# Altium schematic sheet E4_V1.0.SchDoc(E4_V1.0)
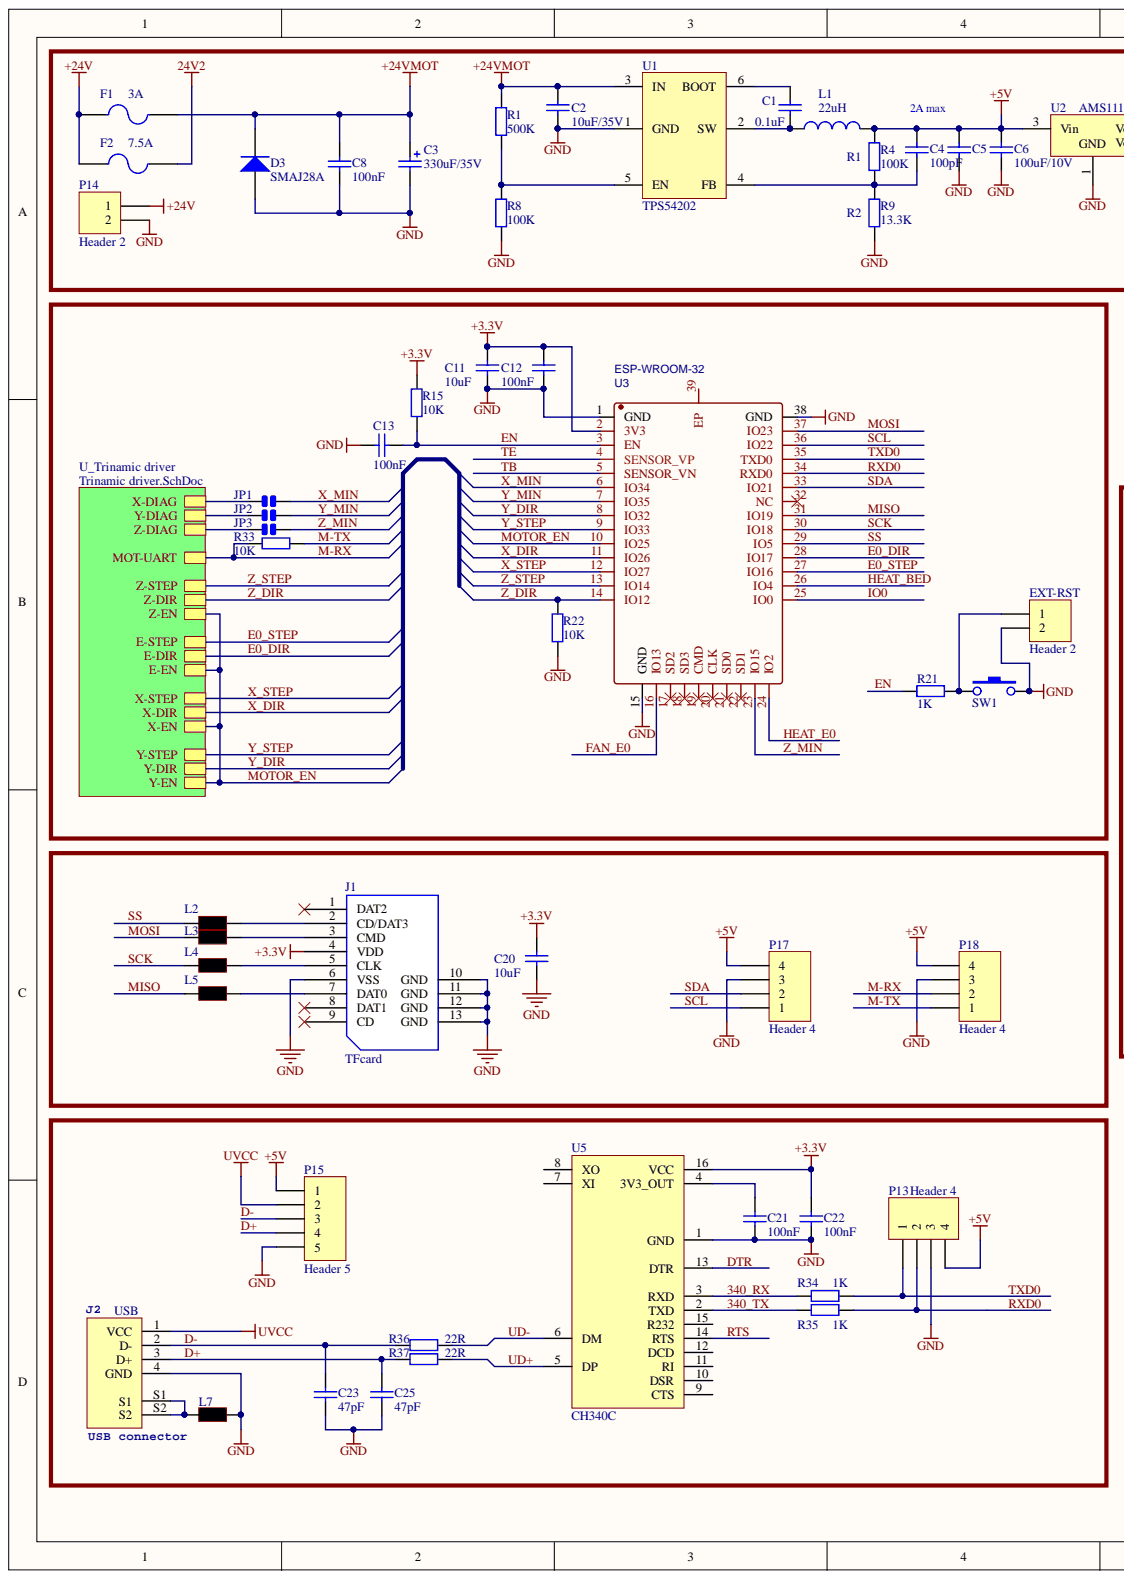
[diagram p0: E4_V1.0.SchDoc, left]
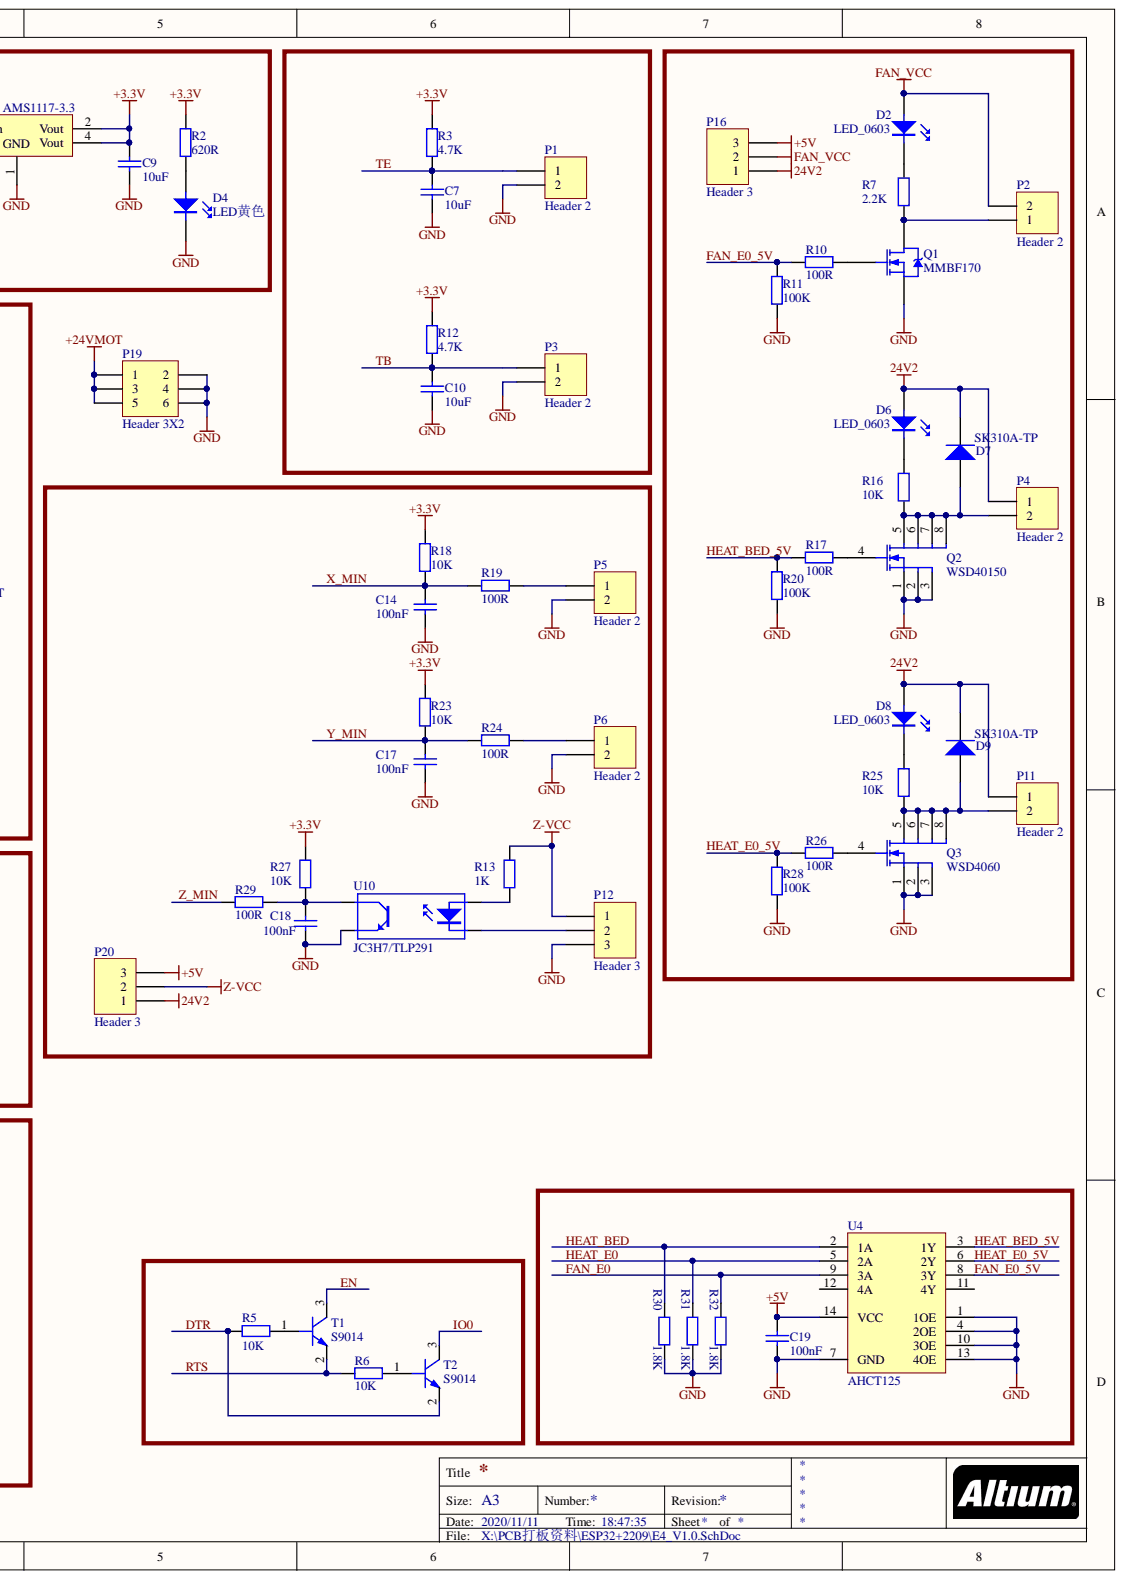
[diagram p1: E4_V1.0.SchDoc, right]

## Parts
C1  0.1uF  [CAP0402]
C2  10uF/35V  [CAP1206]
C3  330uF/35V  [CASE_E7]
C4  100pF  [CAP0402]
C5  100uF/10V  [CAP1206]
C6  100uF/10V  [CAP1206]
C7  10uF  [CAP0805]
C8  100nF  [CAP0603]
C9  10uF  [CAP0805]
C10  10uF  [CAP0805]
C11  10uF  [CAP0805]
C12  100nF  [CAP0402]
C13  100nF  [CAP0402]
C14  100nF  [CAP0402]
C17  100nF  [CAP0402]
C18  100nF  [CAP0402]
C19  100nF  [CAP0402]
C20  10uF  [CAP0603]
C21  100nF  [CAP0402]
C22  100nF  [CAP0402]
C23  47pF  [CAP0402]
C25  47pF  [CAP0402]
D2  LED_0603  [LED0603]
D3  SMAJ28A  [DO214AC (SMA)]
D4  LED黄色  [LED0603]
D6  LED_0603  [LED0603]
D7  SK310A-TP  [DO214AC (SMA)]
D8  LED_0603  [LED0603]
D9  SK310A-TP  [DO214AC (SMA)]
EXT-RST  Header 2  [HDR1X2]
F1  3A  [MCCQ-122]
F2  7.5A  [MCCQ-122]
J1  TFcard  [MicroSD_1]
J2  USB connector  [CON-BERG_PN61729-S]
JP1  Jumper-2P-Open  [HDR1X2]  (value not drawn)
JP2  Jumper-2P-Open  [HDR1X2]  (value not drawn)
JP3  Jumper-2P-Open  [HDR1X2]  (value not drawn)
L1  22uH  [NRS6045]
L2  Bead  [RES0603]  (value not drawn)
L3  Bead  [RES0603]  (value not drawn)
L4  Bead  [RES0603]  (value not drawn)
L5  Bead  [RES0603]  (value not drawn)
L7  Bead  [L0805]  (value not drawn)
P1  Header 2  [XH2.54-2P-L]
P2  Header 2  [XH2.54-2P-L]
P3  Header 2  [XH2.54-2P-L]
P4  Header 2  [KF128/129]
P5  Header 2  [XH2.54-2P-L]
P6  Header 2  [XH2.54-2P-L]
P11  Header 2  [KF128/129]
P12  Header 3  [XH2.54-3P-L]
P13  Header 4  [Header 1X4]
P14  Header 2  [KF128/129]
P15  Header 5  [Header 1X5]
P16  Header 3  [HDR1X3]
P17  Header 4  [Header 1X4]
P18  Header 4  [Header 1X4]
P19  Header 3X2  [Header 2X3]
P20  Header 3  [HDR1X3]
Q1  MMBF170  [SOT23-3]
Q2  WSD40150  [AO_DFN5x6]
Q3  WSD4060  [AO_DFN5x6]
R1  500K  [RES0402]
R2  620R  [RES0402]
R3  4.7K  [RES0603]
R4  100K  [RES0402]
R5  10K  [RES0402]
R6  10K  [RES0402]
R7  2.2K  [RES0402]
R8  100K  [RES0402]
R9  13.3K  [RES0402]
R10  100R  [RES0402]
R11  100K  [RES0402]
R12  4.7K  [RES0603]
R13  1K  [RES0402]
R15  10K  [RES0402]
R16  10K  [RES0402]
R17  100R  [RES0402]
R18  10K  [RES0402]
R19  100R  [RES0402]
R20  100K  [RES0402]
R21  1K  [RES0402]
R22  10K  [RES0402]
R23  10K  [RES0402]
R24  100R  [RES0402]
R25  10K  [RES0402]
R26  100R  [RES0402]
R27  10K  [RES0402]
R28  100K  [RES0402]
R29  100R  [RES0402]
R30  1.8K  [RES0402]
R31  1.8K  [RES0402]
R32  1.8K  [RES0402]
R33  10K  [RES0402]
R34  1K  [RES0402]
R35  1K  [RES0402]
R36  22R  [RES0402]
R37  22R  [RES0402]
SW1  Momentary Tactile Button  [7.5x6x6.5 侧按开关]  (value not drawn)
T1  S9014  [SOT323_3_SC70]
T2  S9014  [SOT323_3_SC70]
U1  TPS54202  [SOT23-6N]
U2  AMS1117-3.3  [SOT-223]
U3  ESP-WROOM-32  [WIFIM-SMD_ESP-WROOM-32]
U4  AHCT125  [QFN14]
U5  CH340C  [SOP16]
U10  JC3H7/TLP291  [SOIC127P720X210-4N]

## Nets
24V2: D6.2 D7.2 D8.2 D9.2 F2.2 P4.1 P11.1 P16.1 P20.1
+3.3V: C9.2 C11.1 C12.2 C20.1 C22.2 J1.4(VDD) R2.1 R3.2 R12.2 R15.2 R18.1 R23.1 R27.1 U2.2(Vout) U2.4(Vout) U3.2(3V3) U5.16(VCC)
+5V: C4.1 C5.1 C6.1 C19.2 L1.2 P13.4 P15.1 P16.3 P17.4 P18.4 P20.3 R4.1 U2.3(Vin) U4.14(VCC)
+24V: F1.1 F2.1 P14.1
+24VMOT: C2.2 C3.1 C8.2 D3.2 F1.2 P19.1 P19.3 P19.5 R1.2 U1.3(IN)
FAN_VCC: D2.2 P2.2 P16.2
GND: C2.1 C3.2 C5.2 C6.2 C7.1 C8.1 C9.1 C10.1 C11.2 C12.1 C13.2 C14.2 C17.2 C18.2 C19.1 C20.2 C21.1 C22.1 C23.1 C25.1 D3.1 D4.1 EXT-RST.2 J1.6(VSS) J1.10(GND) J1.11(GND) J1.12(GND) J1.13(GND) J2.4(GND) L7.2 P1.2 P3.2 P5.2 P6.2 P12.3 P13.3 P14.2 P15.5 P17.3 P18.3 P19.2 P19.4 P19.6 Q1.2 Q2.1 Q2.2 Q2.3 Q3.1 Q3.2 Q3.3 R8.1 R9.2 R11.2 R20.2 R22.1 R28.2 R30.2 R31.2 R32.2 SW1.2 U1.1(GND) U2.1 U3.1(GND) U3.15(GND) U3.38(GND) U4.1(1OE) U4.4(2OE) U4.7(GND) U4.10(3OE) U4.13(4OE) U5.1(GND) U10.3
UVCC: J2.1(VCC) P15.2
Z-VCC: P12.1 P20.2 R13.2
340_RX: R34.1 U5.3(RXD)
340_TX: R35.1 U5.2(TXD)
D+: C25.2 J2.3(D+) P15.4 R37.1
D-: C23.2 J2.2(D-) P15.3 R36.1
DTR: R5.1 T2.2 U5.13(DTR)
E0_DIR: U3.28(IO17)
E0_STEP: U3.27(IO16)
EN: C13.1 R15.1 R21.2 T1.3 U3.3(EN)
FAN_E0: R32.1 U3.16(IO13) U4.9(3A)
FAN_E0_5V: R10.2 R11.1 U4.8(3Y)
HEAT_BED: R30.1 U3.26(IO4) U4.2(1A)
HEAT_BED_5V: R17.2 R20.1 U4.3(1Y)
HEAT_E0: R31.1 U3.24(IO2) U4.5(2A)
HEAT_E0_5V: R26.2 R28.1 U4.6(2Y)
IO0: T2.3 U3.25(IO0)
M-RX: P18.2 R33.2
M-TX: P18.1 R33.1
MISO: L5.1 U3.31(IO19)
MOSI: L3.1 U3.37(IO23)
MOTOR_EN: U3.10(IO25)
RTS: R6.2 T1.2 U5.14(RTS)
RXD0: P13.2 R35.2 U3.34(RXD0)
SCK: L4.1 U3.30(IO18)
SCL: P17.1 U3.36(IO22)
SDA: P17.2 U3.33(IO21)
SS: L2.1 U3.29(IO5)
TB: C10.2 P3.1 R12.1 U3.5(SENSOR_VN)
TE: C7.2 P1.1 R3.1 U3.4(SENSOR_VP)
TXD0: P13.1 R34.2 U3.35(TXD0)
UD+: R37.2 U5.5(DP)
UD-: R36.2 U5.6(DM)
X_DIR: U3.11(IO26)
X_MIN: C14.1 JP1.2 R18.2 R19.2 U3.6(IO34)
X_STEP: U3.12(IO27)
Y_DIR: U3.8(IO32)
Y_MIN: C17.1 JP2.2 R23.2 R24.2 U3.7(IO35)
Y_STEP: U3.9(IO33)
Z_DIR: R22.2 U3.14(IO12)
Z_MIN: JP3.2 R29.2 U3.23(IO15)
Z_STEP: U3.13(IO14)
NetC1_1: C1.1 U1.6(BOOT)
NetC1_2: C1.2 L1.1 U1.2(SW)
NetC4_2: C4.2 R4.2 R9.1 U1.4(FB)
NetC18_1: C18.1 R27.2 R29.1 U10.4
NetC21_2: C21.2 U5.4(3V3_OUT)
NetD2_1: D2.1 R7.2
NetD4_2: D4.2 R2.2
NetD6_1: D6.1 R16.2
NetD7_1: D7.1 P4.2 Q2.5 Q2.6 Q2.7 Q2.8 R16.1
NetD8_1: D8.1 R25.2
NetD9_1: D9.1 P11.2 Q3.5 Q3.6 Q3.7 Q3.8 R25.1
NetEXT-RST_1: EXT-RST.1 R21.1 SW1.1
NetJ1_1: J1.1(DAT2)
NetJ1_2: J1.2(CD/DAT3) L2.2
NetJ1_3: J1.3(CMD) L3.2
NetJ1_5: J1.5(CLK) L4.2
NetJ1_7: J1.7(DAT0) L5.2
NetJ1_8: J1.8(DAT1)
NetJ1_9: J1.9(CD)
NetJ2_S1: J2.S1 J2.S2 L7.1
NetJP1_1: JP1.1
NetJP2_1: JP2.1
NetJP3_1: JP3.1
NetP2_1: P2.1 Q1.3 R7.1
NetP5_1: P5.1 R19.1
NetP6_1: P6.1 R24.1
NetP12_2: P12.2 U10.2
NetQ1_1: Q1.1 R10.1
NetQ2_4: Q2.4 R17.1
NetQ3_4: Q3.4 R26.1
NetR1_1: R1.1 R8.2 U1.5(EN)
NetR5_2: R5.2 T1.1
NetR6_1: R6.1 T2.1
NetR13_1: R13.1 U10.1
NetU3_17: U3.17(SD2)
NetU3_18: U3.18(SD3)
NetU3_19: U3.19(CMD)
NetU3_20: U3.20(CLK)
NetU3_21: U3.21(SD0)
NetU3_22: U3.22(SD1)
NetU3_32: U3.32(NC)
NetU3_39: U3.39(EP)
NetU4_11: U4.11(4Y)
NetU4_12: U4.12(4A)
NetU5_7: U5.7(XI)
NetU5_8: U5.8(XO)
NetU5_9: U5.9(CTS)
NetU5_10: U5.10(DSR)
NetU5_11: U5.11(RI)
NetU5_12: U5.12(DCD)
NetU5_15: U5.15(R232)
N00028:

## Library data of the parts
C1 = Library_CAP-0008: RO C0G 22pF 2% 50V  0603; Value: 100nF; Faber ItemCode: 35321100; ID: Library_CAP-0008; Component Kind: Standard; Library Name: Ultimaker.IntLib
C2 C7-C13 C20 = Capacitor: Component Kind: Standard; Library Name: 接插件库.SCHLIB
C3 = Library_CAP-0004: RO ELCO 100uF 20% 35V CASE-D EEEFP PAN; Faber ItemCode: 36865800; ID: Library_CAP-0004; Component Kind: Standard; Library Name: Ultimaker.IntLib
C4 = Library_CAP-0008: RO C0G 22pF 2% 50V  0603; Value: 47pF; Faber ItemCode: 35321100; ID: Library_CAP-0008; Component Kind: Standard; Library Name: Ultimaker.IntLib
C5 C6 = Library_CAP-0008: RO C0G 22pF 2% 50V  0603; Value: 47uF; Faber ItemCode: 35321100; ID: Library_CAP-0008; Component Kind: Standard; Library Name: Ultimaker.IntLib
C14 C17-C19 = Library_CAP-0008: RO C0G 22pF 2% 50V  0603; Faber ItemCode: 35321100; Value: 100nF; ID: Library_CAP-0008; Component Kind: Standard; Library Name: Ultimaker.IntLib
C21 C22 = Library_CAP-0006: RO X5R 1uF 10% 10V 0603; Faber ItemCode: 36310600; Value: 100nF; ID: Library_CAP-0006; Component Kind: Standard; Library Name: Ultimaker.IntLib
C23 C25 = Library_CAP-0002: RO X7R 100nF 10% 50V 0603; Faber ItemCode: 45068500; Value: 47pF; ID: Library_CAP-0002; Component Kind: Standard; Library Name: Ultimaker.IntLib
D3 D7 D9 = Diode: Default Diode; Simulation: DIODE; Signal Integrity: Diode; Published: 8-Jun-2000; PackageVersion: Sep-1996; PackageReference: SMC; PackageDescription: DO-214-AB/SMC; 2 C-Bend Leads; Body 7.9 x 5.9 mm, inc. leads (LxW); Library Name: Miscellaneous Devices.IntLib; LatestRevisionNote: Re-released for DXP Platform.; LatestRevisionDate: 17-Jul-2002; Component Kind: Standard; Code_JEDEC: DO-214-AB; Publisher: Altium Limited
D4 = LED_0603: Component Kind: Standard; Library Name: 接插件库.SCHLIB
EXT-RST P1-P6 P11 P14 = Header 2: Header, 2-Pin; Signal Integrity: Connector; Library Name: Miscellaneous Connectors.IntLib; LatestRevisionNote: Re-released for DXP Platform.; LatestRevisionDate: 17-Jul-2002; Component Kind: Standard; Publisher: Altium Limited
F1 F2 = Fuse 2: Fuse; Simulation: FUSE; Published: 8-Jun-2000; PackageReference: PIN-W2/E2.8; PackageDescription: Thru-Hole; 2 Leads; Library Name: Miscellaneous Devices.IntLib; LatestRevisionNote: Re-released for DXP Platform.; LatestRevisionDate: 17-Jul-2002; Component Kind: Standard; Publisher: Altium Limited
J2 = CON-BERG_PN61729-S: OC_NEWARK: unknown; OC_FARNELL: unknown; Component Kind: Standard; Library Name: 接插件库.SCHLIB
L1 = Inductor: Code_IEC: 1005; Value: 22uH; Simulation: INDUCTOR; Signal Integrity: Inductor; Published: 8-Jun-2000; PackageReference: 0402-A; PackageDescription: Chip Inductor; Library Name: Miscellaneous Devices.IntLib; LatestRevisionNote: IPC-7351 Footprint Added.; LatestRevisionDate: 29-May-2009; Description: Inductor; Component Kind: Standard; Code_JEITA: 0402; Publisher: Altium Limited
L2-L5 = (no symbol name): RO FERRIET BLM21PG221SN1D  0805; ID: Library_COIL-0001; Faber ItemCode: 36571200; Value: Bead; Component Kind: Standard; Library Name: Ultimaker2.SCHLIB
L7 = Library_COIL-0001: RO FERRIET BLM21PG221SN1D  0805; Faber ItemCode: 36571200; Value: Bead; ID: Library_COIL-0001; Component Kind: Standard; Library Name: Ultimaker.IntLib
P12 P16 P20 = Header 3: Header, 3-Pin; Signal Integrity: Connector; Library Name: Miscellaneous Connectors.IntLib; LatestRevisionNote: Re-released for DXP Platform.; LatestRevisionDate: 17-Jul-2002; Component Kind: Standard; Publisher: Altium Limited
P13 P17 P18 = Header 4: Header, 4-Pin; Signal Integrity: Connector; Library Name: Miscellaneous Connectors.IntLib; LatestRevisionNote: Re-released for DXP Platform.; LatestRevisionDate: 17-Jul-2002; Component Kind: Standard; Publisher: Altium Limited
P15 = Header 5: Header, 5-Pin; Signal Integrity: Connector; Library Name: Miscellaneous Connectors.IntLib; LatestRevisionNote: Re-released for DXP Platform.; LatestRevisionDate: 17-Jul-2002; Component Kind: Standard; Publisher: Altium Limited
P19 = Header 3X2: Header, 3-Pin, Dual row; Signal Integrity: Connector; Library Name: Miscellaneous Connectors.IntLib; LatestRevisionNote: Re-released for DXP Platform.; LatestRevisionDate: 17-Jul-2002; Component Kind: Standard; Publisher: Altium Limited
Q1 = N-MOS: Component Kind: Standard; Library Name: 接插件库.SCHLIB
Q2 Q3 = (no symbol name): IRF8736PBF - MOSFET, N SO-8; Mfg: INTERNATIONAL RECTIFIER; Info: HEXFET Power MOSFET; ID: LIBRARY_FET-0002; Faber ItemCode: 36926000; Value: IRF8736PBF; Mfg code: IRF8736PBF; Component Kind: Standard; Library Name: Ultimaker2.SCHLIB
R1 R3 R7 R8 R10-R13 R15-R33 = Resistor: Component Kind: Standard; Library Name: 接插件库.SCHLIB
R2 R4 = Library_RES-0001: RO RES 10k0 1% 0,063W  0603; Value: 49.9k; Info: Resistor; Faber ItemCode: 36084500; ID: Library_RES-0001; Component Kind: Standard; Library Name: Ultimaker.IntLib
R5 R6 R34-R37 = Library_RES-0007: RO RES 22E0 1% 0,063W 0603; Info: Resistor; Faber ItemCode: 36108300; ID: Library_RES-0007; Component Kind: Standard; Library Name: Ultimaker.IntLib
  R5 R6: Value: 10K
  R34 R35: Value: 1K
  R36 R37: Value: 22R
R9 = Library_RES-0001: RO RES 10k0 1% 0,063W  0603; Value: 9.53k; Info: Resistor; Faber ItemCode: 36084500; ID: Library_RES-0001; Component Kind: Standard; Library Name: Ultimaker.IntLib
SW1 = SW-PB: SWITCH TACTILE SPST-NO 0.05A 12V; Note: Approved sub OK; Supplier Part Number 1: 679-2396-1-ND; Supplier 1: Digi-Key; Component Kind: Standard; Library Name: picoprint_library.SCHLIB
T1 T2 = (no symbol name): RO TRANS BC817-25  SOT-23; Info: 45 V, 500 mA NPN general-purpose transistors; ID: Library_TRA-0001; Faber ItemCode: 30828000; Value: S9014; Component Kind: Standard; Library Name: Ultimaker2.SCHLIB
U2 = 1117: Component Kind: Standard; Library Name: 接插件库.SCHLIB
U3 = ESP-WROOM-32: BOM_Manufacturer: ESPRESSIF; spiceSymbolName: ESP-WROOM-32; spicePre: U; Library Name: ESP_WIFI.SCHLIB; Component Kind: Standard; BOM_Supplier Part: C95209; BOM_Supplier: LCSC; BOM_Manufacturer Part: ESP-WROOM-32
U4 = 74HC125: Component Kind: Standard; Library Name: 接插件库.SCHLIB
U5 = CH340G: Component Kind: Standard; Library Name: 接插件库.SCHLIB
U10 = Optoisolator1: 4-Pin Phototransistor Optocoupler; Published: 24-Mar-1999; PackageReference: DIP-4; Library Name: Miscellaneous Devices.IntLib; LatestRevisionNote: Sch pins 3 & 4 Swapped.; LatestRevisionDate: 04-Mar-2005; DatasheetVersion: Nov-2003; Component Kind: Standard; Publisher: Altium Limited
D2 D6 D8 = LED_0603: Component Kind: Standard; Library Name: 接插件库.SCHLIB
J1 = TFcard: Library Name: SD&TF.SchLib; Component Kind: Standard
JP1-JP3 = Jumper-2P-Open: Component Kind: Standard; Library Name: 接插件库.SCHLIB
U1 = TPS54202: Component Kind: Standard; Library Name: 接插件库.SCHLIB
